# Altium schematic sheet Main.SchDoc
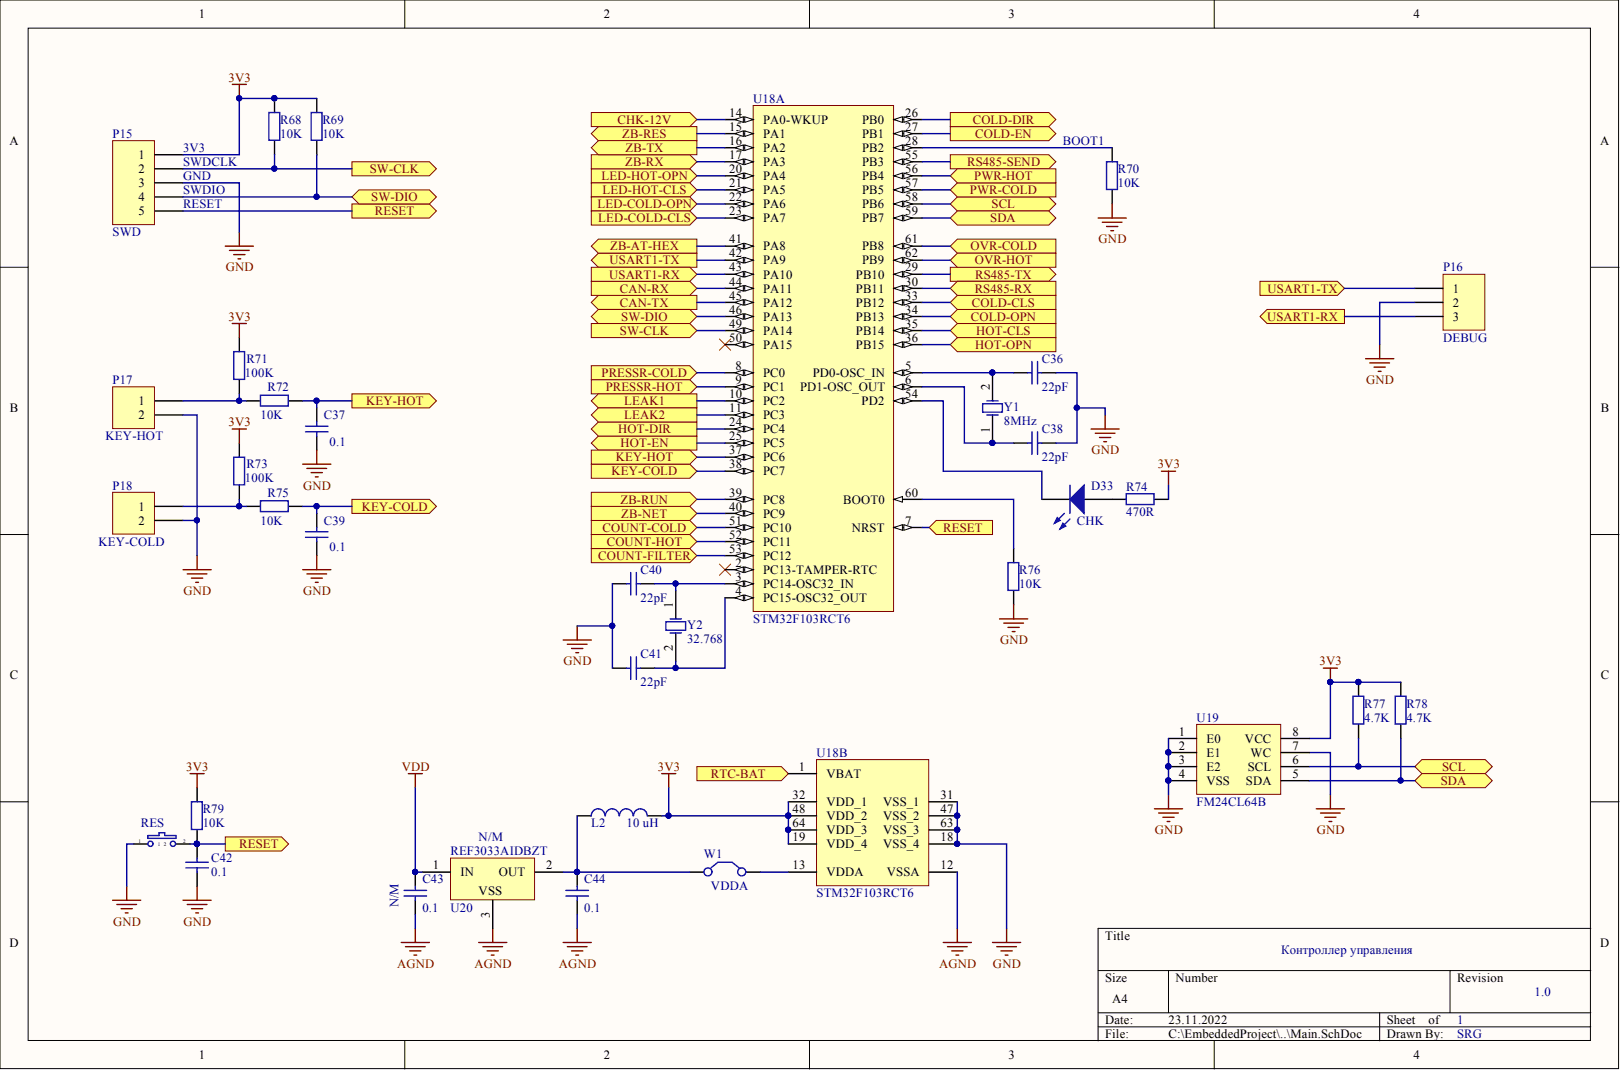
[diagram p0: Main.SchDoc]

## Parts
C36  value 22pF  [C1206]  (hidden comment: Cap)
C37  value 0.1  [C1206]  (hidden comment: Cap)
C38  value 22pF  [C1206]  (hidden comment: Cap)
C39  value 0.1  [C1206]  (hidden comment: Cap)
C40  value 22pF  [C1206]  (hidden comment: Cap)
C41  value 22pF  [C1206]  (hidden comment: Cap)
C42  value 0.1  [C1206]  (hidden comment: Cap)
C43  value 0.1  Mount: N/M  [C1206]  (hidden comment: Cap)
C44  value 0.1  [C1206]  (hidden comment: Cap)
D33  CHK  [LED1206 - GREEN]
L2  value 10 uH  [L1206]  (hidden comment: Ferrite Chip Beads)
P15  SWD  [HDR1X5]
P16  DEBUG  [HDR1X3]
P17  KEY-HOT  [WF-2]
P18  KEY-COLD  [WF-2]
R68  value 10K  [R1206]  (hidden comment: Res2)
R69  value 10K  [R1206]  (hidden comment: Res2)
R70  value 10K  [R1206]  (hidden comment: Res2)
R71  value 100K  [R1206]  (hidden comment: Res2)
R72  value 10K  [R1206]  (hidden comment: Res2)
R73  value 100K  [R1206]  (hidden comment: Res2)
R74  value 470R  [R1206]  (hidden comment: 520)
R75  value 10K  [R1206]  (hidden comment: Res2)
R76  value 10K  [R1206]  (hidden comment: Res2)
R77  value 4.7K  [R1206]  (hidden comment: Res2)
R78  value 4.7K  [R1206]  (hidden comment: Res2)
R79  value 10K  [R1206]  (hidden comment: Res2)
RES  KLS7-TS3608-5.0-180  [KLS7-TS3608]  (value not drawn)
U18  STM32F103RCT6  [STM-LQFP64_N]  (parts A,B)
U19  FM24CL64B  [SO8_M]
U20  REF3033AIDBZT  Mount: N/M  [SOT-23-TT3_N]
W1  VDDA  [JUMPER SHORT]
Y1  value 8MHz  [HC49/4H_SMX]  (hidden comment: XTAL)
Y2  value 32.768  [LED]  (hidden comment: XTAL)

## Ports
CAN-RX, CAN-TX, CHK-12V, COLD-CLS, COLD-DIR, COLD-EN, COLD-OPN, COUNT-COLD, COUNT-FILTER, COUNT-HOT, HOT-CLS, HOT-DIR, HOT-EN, HOT-OPN, KEY-COLD, KEY-HOT, LEAK1, LEAK2, LED-COLD-CLS, LED-COLD-OPN, LED-HOT-CLS, LED-HOT-OPN, OVR-COLD, OVR-HOT, PRESSR-COLD, PRESSR-HOT, PWR-COLD, PWR-HOT, RESET, RS485-RX, RS485-SEND, RS485-TX, RTC-BAT, SCL, SDA, SW-CLK, SW-DIO, USART1-RX, USART1-TX, ZB-AT-HEX, ZB-NET, ZB-RES, ZB-RUN, ZB-RX, ZB-TX

## Nets
3V3: L2.2 P15.1 R68.2 R69.2 R71.1 R73.1 R74.1 R77.2 R78.2 R79.2 U18.19(VDD_4) U18.32(VDD_1) U18.48(VDD_2) U18.64(VDD_3) U19.8(VCC)
AGND: C43.1 C44.1 U18.12(VSSA) U20.3
GND: C36.2 C37.2 C38.2 C39.2 C40.1 C41.1 C42.1 P15.3 P16.2 P17.2 P18.2 R70.1 R76.1 RES.1 U18.18(VSS_4) U18.31(VSS_1) U18.47(VSS_2) U18.63(VSS_3) U19.1(E0) U19.2(E1) U19.3(E2) U19.4(VSS) U19.7(WC)
VDD: C43.2 U20.1
CAN-RX: U18.44(PA11) ; port CAN-RX
CAN-TX: U18.45(PA12) ; port CAN-TX
CHK-12V: U18.14(PA0-WKUP) ; port CHK-12V
COLD-CLS: U18.33(PB12) ; port COLD-CLS
COLD-DIR: U18.26(PB0) ; port COLD-DIR
COLD-EN: U18.27(PB1) ; port COLD-EN
COLD-OPN: U18.34(PB13) ; port COLD-OPN
COUNT-COLD: U18.51(PC10) ; port COUNT-COLD
COUNT-FILTER: U18.53(PC12) ; port COUNT-FILTER
COUNT-HOT: U18.52(PC11) ; port COUNT-HOT
HOT-CLS: U18.35(PB14) ; port HOT-CLS
HOT-DIR: U18.24(PC4) ; port HOT-DIR
HOT-EN: U18.25(PC5) ; port HOT-EN
HOT-OPN: U18.36(PB15) ; port HOT-OPN
KEY-COLD: C39.1 R75.1 U18.38(PC7) ; port KEY-COLD
KEY-HOT: C37.1 R72.1 U18.37(PC6) ; port KEY-HOT
LEAK1: U18.10(PC2) ; port LEAK1
LEAK2: U18.11(PC3) ; port LEAK2
LED-COLD-CLS: U18.23(PA7) ; port LED-COLD-CLS
LED-COLD-OPN: U18.22(PA6) ; port LED-COLD-OPN
LED-HOT-CLS: U18.21(PA5) ; port LED-HOT-CLS
LED-HOT-OPN: U18.20(PA4) ; port LED-HOT-OPN
OVR-COLD: U18.61(PB8) ; port OVR-COLD
OVR-HOT: U18.62(PB9) ; port OVR-HOT
PRESSR-COLD: U18.8(PC0) ; port PRESSR-COLD
PRESSR-HOT: U18.9(PC1) ; port PRESSR-HOT
PWR-COLD: U18.57(PB5) ; port PWR-COLD
PWR-HOT: U18.56(PB4) ; port PWR-HOT
RESET: C42.2 P15.5 R79.1 RES.2 U18.7(NRST) ; port RESET
RS485-RX: U18.30(PB11) ; port RS485-RX
RS485-SEND: U18.55(PB3) ; port RS485-SEND
RS485-TX: U18.29(PB10) ; port RS485-TX
RTC-BAT: U18.1(VBAT) ; port RTC-BAT
SCL: R77.1 U18.58(PB6) U19.6(SCL) ; port SCL
SDA: R78.1 U18.59(PB7) U19.5(SDA) ; port SDA
SW-CLK: P15.2 R68.1 U18.49(PA14) ; port SW-CLK
SW-DIO: P15.4 R69.1 U18.46(PA13) ; port SW-DIO
USART1-RX: P16.3 U18.43(PA10) ; port USART1-RX
USART1-TX: P16.1 U18.42(PA9) ; port USART1-TX
ZB-AT-HEX: U18.41(PA8) ; port ZB-AT-HEX
ZB-NET: U18.40(PC9) ; port ZB-NET
ZB-RES: U18.15(PA1) ; port ZB-RES
ZB-RUN: U18.39(PC8) ; port ZB-RUN
ZB-RX: U18.17(PA3) ; port ZB-RX
ZB-TX: U18.16(PA2) ; port ZB-TX
NetC36_1: C36.1 U18.5(PD0-OSC_IN) Y1.2
NetC38_1: C38.1 U18.6(PD1-OSC_OUT) Y1.1
NetC40_2: C40.2 U18.3(PC14-OSC32_IN) Y2.1
NetC41_2: C41.2 U18.4(PC15-OSC32_OUT) Y2.2
NetC44_2: C44.2 L2.1 U20.2 W1.1
NetD33_1: D33.1 R74.2
NetD33_2: D33.2 U18.54(PD2)
NetP17_1: P17.1 R71.2 R72.2
NetP18_1: P18.1 R73.2 R75.2
NetR70_2: R70.2 U18.28(PB2)
NetR76_2: R76.2 U18.60(BOOT0)
NetU18_13: U18.13(VDDA) W1.2

Not connected: U18 2(PC13-TAMPER-RTC) 50(PA15)

## Library data of the parts
C36-C41 = Cap: SMD 1206 Capasitor Body 3.2 x 1.6 mm; Component Kind: Standard; LatestRevisionDate: 17-Jul-2002; LatestRevisionNote: Re-released for DXP Platform.; Library Name: Miscellaneous Devices.IntLib; PackageReference: RAD-0.3; Published: 8-Jun-2000; Signal Integrity: Cap; Simulation: CAP; Publisher: Altium Limited
C42-C44 = Cap: SMD 1206 Capasitor Body 3.2 x 1.6 mm; PartNumber: GRM31CR72A104K; DeliveryTime: 7; Component Kind: Standard; Library Name: Miscellaneous Devices.IntLib; Price: 10.00; Signal Integrity: Cap; Simulation: CAP
D33 = LED1: SMD LED; Value: GREEN; Component Kind: Standard; LatestRevisionDate: 08-Jul-2005; LatestRevisionNote: Mech Layer 1 removed.; Library Name: Miscellaneous Devices.IntLib; PackageReference: LED-1; Published: 8-Jun-2000; Simulation: LED1; Publisher: Altium Limited
L2 = Inductor: PartNumber: LQM31PN4R7M00L; Code_IEC: 1005; Mount: Not mount; Code_JEITA: 0402; Component Kind: Standard; Description: Ferrite Chip Beads; LatestRevisionDate: 29-May-2009; LatestRevisionNote: IPC-7351 Footprint Added.; Library Name: Miscellaneous Devices.IntLib; PackageDescription: Chip Inductor; PackageReference: 0402-A; Published: 8-Jun-2000; Signal Integrity: Inductor; Simulation: INDUCTOR; Publisher: Altium Limited
P15 = Header 5: Header, 5-Pin; Value: HDR1X5; Component Kind: Standard; LatestRevisionDate: 17-Jul-2002; LatestRevisionNote: Re-released for DXP Platform.; Library Name: Miscellaneous Connectors.IntLib; Signal Integrity: Connector; Publisher: Altium Limited
P16 = Header 3: Header, 3-Pin; Value: HDR1X3; Component Kind: Standard; LatestRevisionDate: 17-Jul-2002; LatestRevisionNote: Re-released for DXP Platform.; Library Name: Miscellaneous Connectors.IntLib; Signal Integrity: Connector; Publisher: Altium Limited
P17 P18 = Header 2: Header, 2-Pin; Value: WF-2; Component Kind: Standard; LatestRevisionDate: 17-Jul-2002; LatestRevisionNote: Re-released for DXP Platform.; Library Name: Miscellaneous Connectors.IntLib; Signal Integrity: Connector; Publisher: Altium Limited
R68-R70 R76-R79 = Res2: SMD 1206 Resistor Body 3.2 x 1.6 mm; PartNumber: RC1206JK-0710KL; DeliveryTime: 7; Component Kind: Standard; Library Name: Miscellaneous Devices.IntLib; Price: 0.90; Signal Integrity: Res; Simulation: RESISTOR
R71-R75 = Res2: SMD 1206 Resistor Body 3.2 x 1.6 mm; Component Kind: Standard; LatestRevisionDate: 17-Jul-2002; LatestRevisionNote: Re-released for DXP Platform.; Library Name: Miscellaneous Devices.IntLib; PackageReference: AXIAL-0.4; Published: 8-Jun-2000; Signal Integrity: Res; Simulation: RESISTOR; Publisher: Altium Limited
  R72 R75: PackageDescription: Axial Device, Thru-Hole; 2 Leads; 0.4 in Pin Spacing
RES = Buton TS-06: Key Switch; Value: Button; Component Kind: Standard; Library Name: Devise.IntLib
U18 = STM32F103RCT6: ARM Cortex-M3 32-bit MCU, 256 KB Flash, 48 KB Internal RAM, 51 I/Os, 64-pin LQFP, -40 to 85 degC, Tray; A/D Converter: 3x12-bit (16 Channel); D/A Converter: 2x12-bit (2 Channel); FLASH Size (Prog) (kB): 256; I/Os (High Current): 51; Internal RAM Size (kB): 48; Operating Frequency(F) (Processor speed) (MHz): 72; Supply Voltage(Vcc) min (V): 2; Component Kind: Standard; ComponentLink1Description: Manufacturer URL; ComponentLink1URL: http://www.st.com/web/en/home.html; ComponentLink2Description: Datasheet; ComponentLink2URL: http://www.st.com/st-web-ui/static/active/en/resource/technical/document/datasheet/CD00191185.pdf; ComponentLink3Description: Package Specification; ComponentLink3URL: http://www.st.com/st-web-ui/static/active/en/resource/technical/document/datasheet/DM00037051.pdf; Core: ARM Cortex-M3; DatasheetVersion: Rev. 8; Library Name: STMicroelectronics STM32 F1.IntLib; Mounting Technology: Surface Mount; PackageDescription: 64-Pin Low Profile Quad Flat Package, 10 x 10 mm Body, 0.5 mm Pitch; PackageReference: LQFP64; PackageVersion: Rev. 4, 06/2013; Packing: Tray; PartNumber: STM32F103RCT6; Supplier 1: Digi-Key; Supplier 2: Newark; Supplier 3: Newark; Supplier 4: Mouser; Supplier Part Number 1: 497-9033-ND; Supplier Part Number 2: 01P4099; Supplier Part Number 3: 57P1227; Supplier Part Number 4: 511-STM32F103RCT6
U19 = FM24CL64B: 64-Kbit (8K × 8) Serial (I2C) F-RAM 3V3; Component Kind: Standard; Library Name: Schlib1.SchLib; Value: FM24CL64B
U20 = MCP1525T-I/TT: 2.5V Voltage Reference, 3-Pin SOT-23, Industrial Temperature, Tape and Reel; Code_IPC: SOT23-3; Component Kind: Standard; ComponentLink1Description: Manufacturer URL; ComponentLink1URL: http://www.microchip.com/; ComponentLink2Description: Datasheet; ComponentLink2URL: http://ww1.microchip.com/downloads/en/DeviceDoc/21653b.pdf; ComponentLink3Description: Package Specification; ComponentLink3URL: http://www.microchip.com/stellent/groups/techpub_sg/documents/packagingspec/en012702.pdf; DatasheetVersion: revB, Feb-2005; Library Name: Microchip Power Management Voltage Reference.IntLib; PackageDescription: 3-Lead Plastic Small Outline Transistor (TT) [SOT-23]; PackageReference: SOT-23-TT3; PackageVersion: revBB, Aug-2009; Value: REF3033AIDBZT
W1 = Jumper: Jumper Wire; Component Kind: Standard; LatestRevisionDate: 17-Jul-2002; LatestRevisionNote: Re-released for DXP Platform.; Library Name: Miscellaneous Devices.IntLib; PackageDescription: Radial Cap, Thru-Hole; 2 Leads; 0.2 in Pin Spacing; PackageReference: RAD-0.2; Published: 24-Mar-1999; Publisher: Altium Limited
Y1 = XTAL: Crystal Oscillator; PartNumber: LFXTAL020423; DeliveryTime: 21; Component Kind: Standard; Library Name: Miscellaneous Devices.IntLib; Price: 57.00; Simulation: XTAL
Y2 = XTAL: Crystal Oscillator; PartNumber: MCRJ332768F1220HOW; DeliveryTime: 21; Component Kind: Standard; Library Name: Miscellaneous Devices.IntLib; Price: 28; Simulation: XTAL
